# Altium schematic sheet main_power_circuit.SchDoc(main_power_circuit)
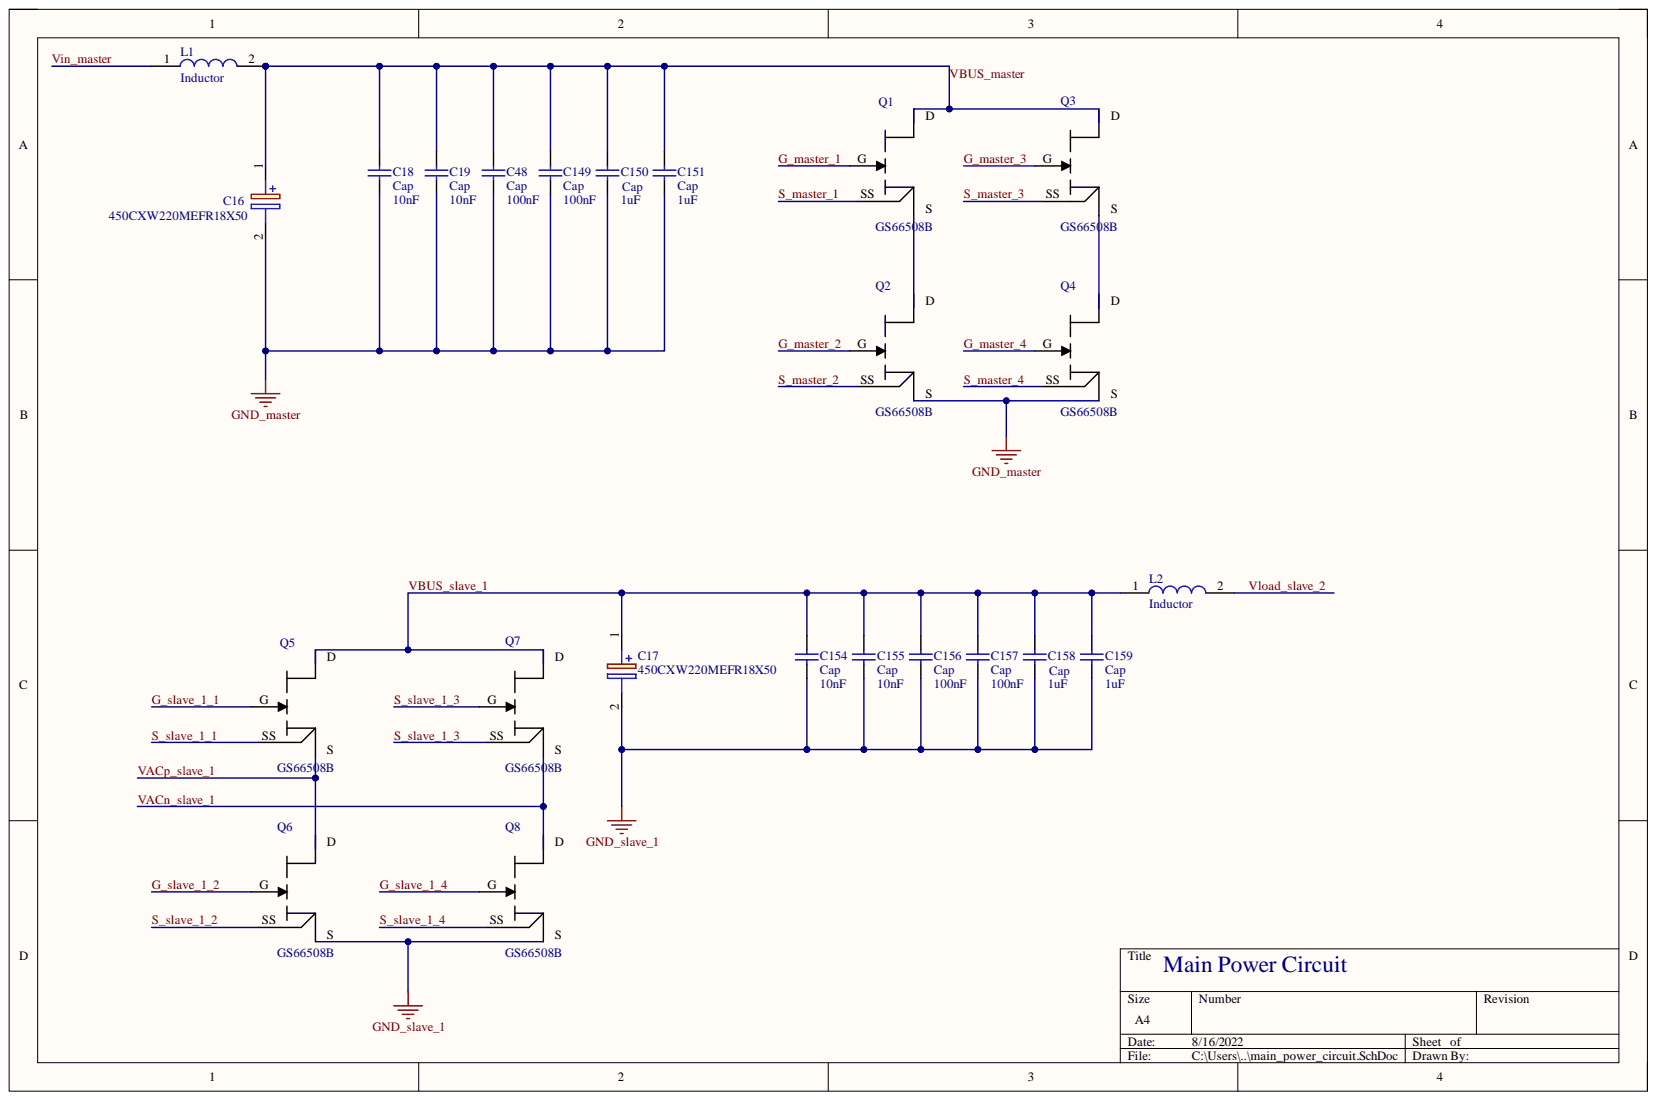
[diagram p0: main_power_circuit.SchDoc]

## Parts
C16  450CXW220MEFR18X50  [CAPPRD750W80D1825H5200]
C17  450CXW220MEFR18X50  [CAPPRD750W80D1825H5200]
C18  Cap  value 10nF  [C0805]
C19  Cap  value 10nF  [C0805]
C48  Cap  value 100nF  [C0805]
C149  Cap  value 100nF  [C0805]
C150  Cap  value 1uF  [C0805]
C151  Cap  value 1uF  [C0805]
C154  Cap  value 10nF  [C0805]
C155  Cap  value 10nF  [C0805]
C156  Cap  value 100nF  [C0805]
C157  Cap  value 100nF  [C0805]
C158  Cap  value 1uF  [C0805]
C159  Cap  value 1uF  [C0805]
L1  Inductor  [B82477R4]
L2  Inductor  [B82477R4]
Q1  GS66508B  [GS66508B]
Q2  GS66508B  [GS66508B]
Q3  GS66508B  [GS66508B]
Q4  GS66508B  [GS66508B]
Q5  GS66508B  [GS66508B]
Q6  GS66508B  [GS66508B]
Q7  GS66508B  [GS66508B]
Q8  GS66508B  [GS66508B]

## Nets
GND_master: C16.2 C18.1 C19.1 C48.1 C149.1 C150.1 C151.1 Q2.S Q4.S
GND_slave_1: C17.2 C154.1 C155.1 C156.1 C157.1 C158.1 C159.1 Q6.S Q8.S
G_master_1: Q1.G
G_master_2: Q2.G
G_master_3: Q3.G
G_master_4: Q4.G
G_slave_1_1: Q5.G
G_slave_1_2: Q6.G
G_slave_1_4: Q8.G
S_master_1: Q1.SS
S_master_2: Q2.SS
S_master_3: Q3.SS
S_master_4: Q4.SS
S_slave_1_1: Q5.SS
S_slave_1_2: Q6.SS
S_slave_1_3: Q7.G Q7.SS
S_slave_1_4: Q8.SS
VACn_slave_1: Q7.S Q8.D
VACp_slave_1: Q5.S Q6.D
VBUS_master: C16.1 C18.2 C19.2 C48.2 C149.2 C150.2 C151.2 L1.2 Q1.D Q3.D
VBUS_slave_1: C17.1 C154.2 C155.2 C156.2 C157.2 C158.2 C159.2 L2.1 Q5.D Q7.D
Vin_master: L1.1
Vload_slave_2: L2.2
NetQ1_S: Q1.S Q2.D
NetQ3_S: Q3.S Q4.D

## Library data of the parts
C16 C17 = 450CXW220MEFR18X50: Capacitor Polarised; Manufacturer_Name: Rubycon; Component Kind: Standard; Datasheet Link: https://www.mouser.in/ProductDetail/Rubycon/450CXW220MEFR18X50?qs=T3oQrply3y%252BgZzrWksPWmQ%3D%3D; Height: 52mm; Manufacturer_Part_Number: 450CXW220MEFR18X50
C18 C19 C48 C149-C151 C154-C159 = Cap: Capacitor; Component Kind: Standard; LatestRevisionDate: 17-Jul-2002; LatestRevisionNote: Re-released for DXP Platform.; Library Name: Miscellaneous Devices.IntLib; PackageDescription: Radial Cap, Thru-Hole; 2 Leads; 0.3 in Pin Spacing; PackageReference: RAD-0.3; Published: 8-Jun-2000; Signal Integrity: Cap; Simulation: CAP; Publisher: Altium Limited
L1 L2 = B82477R4302M100: Manufacturer_Name: TDK; Component Kind: Standard; Datasheet Link: https://product.tdk.com/system/files/dam/doc/product/inductor/inductor/smd/data_sheet/30/ds/b82477r4.pdf; Description: Inductor; Height: 8.5mm; Library Name: B82477R4302M100.SchLib; Manufacturer_Part_Number: B82477R4302M100
Q1-Q8 = GS66508B: GAN TRANS E-MODE 650V 30A; Component Kind: Standard; Library Name: GANSYS_ALTIUM_SYMBOL_20220201.SchLib
